# Altium schematic sheet [7]Manettini_e_Bottoni.SchDoc([7]Manettini_e_Bottoni)
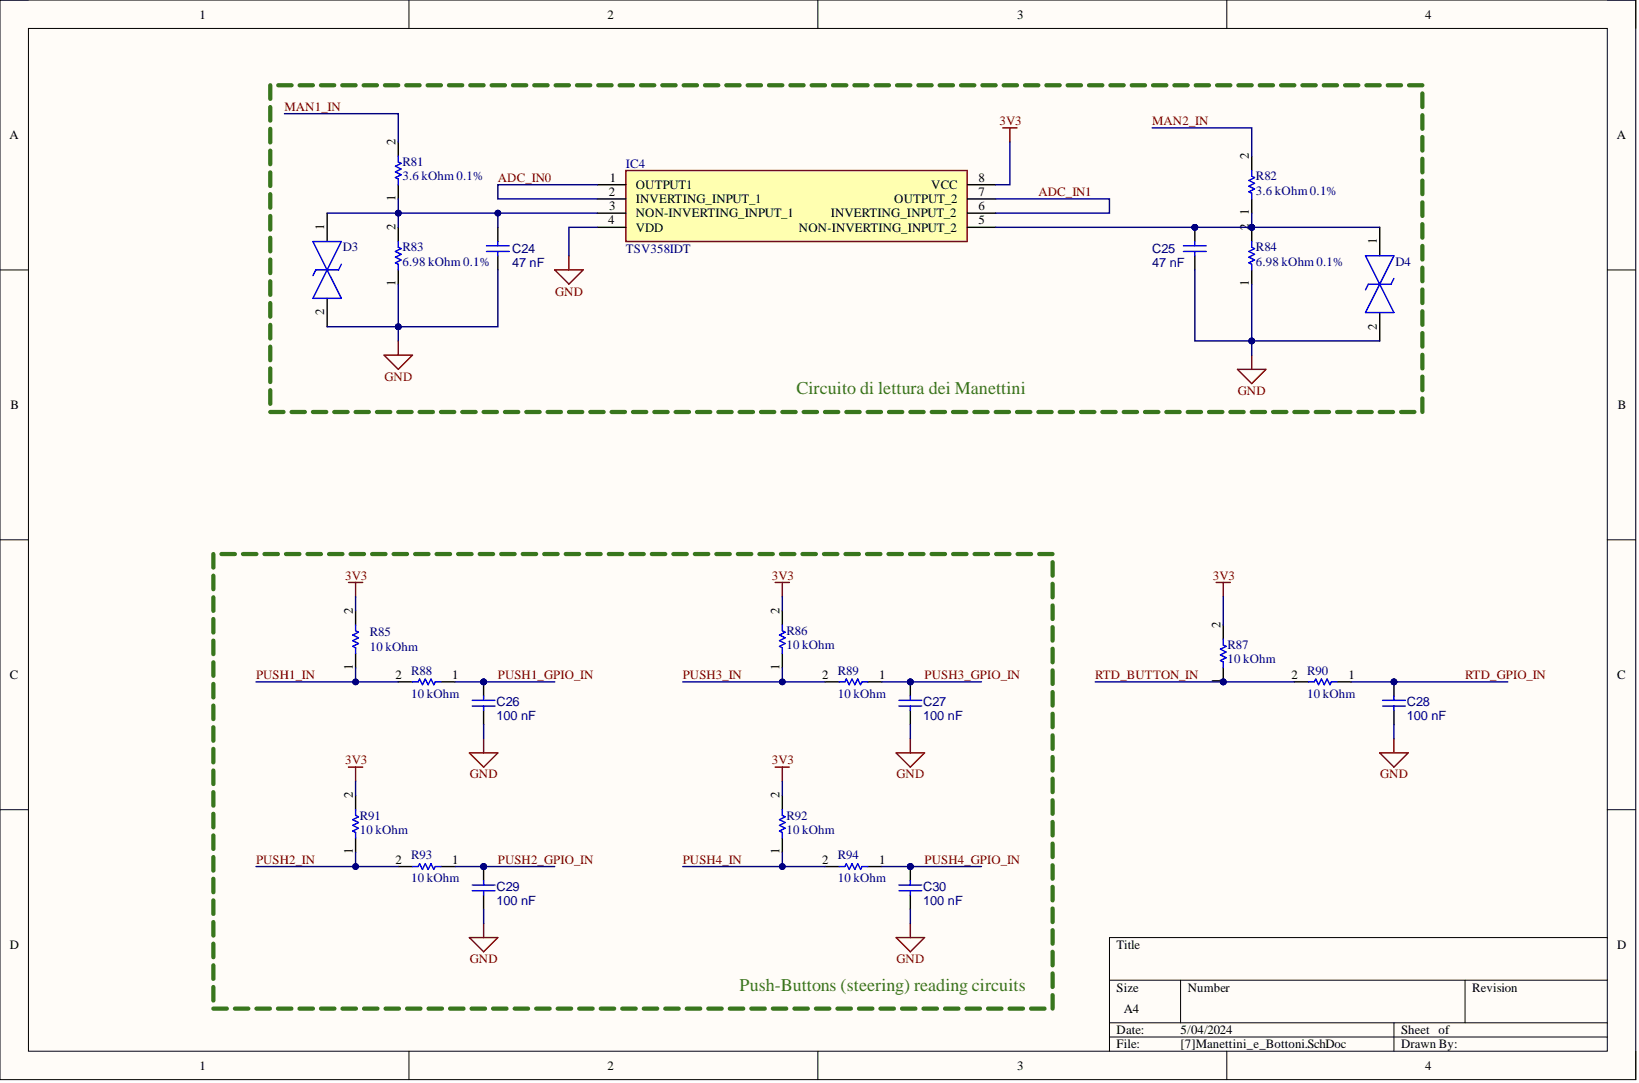
[diagram p0: [7]Manettini_e_Bottoni.SchDoc]

## Parts
C24  47 nF
C25  47 nF
C26  100 nF
C27  100 nF
C28  100 nF
C29  100 nF
C30  100 nF
D3  SMAJ30CA-TR  (value not drawn)
D4  SMAJ30CA-TR  (value not drawn)
IC4  TSV358IDT
R81  3.6 kOhm 0.1%
R82  3.6 kOhm 0.1%
R83  6.98 kOhm 0.1%
R84  6.98 kOhm 0.1%
R85  10 kOhm
R86  10 kOhm
R87  10 kOhm
R88  10 kOhm
R89  10 kOhm
R90  10 kOhm
R91  10 kOhm
R92  10 kOhm
R93  10 kOhm
R94  10 kOhm

## Nets
3V3: IC4.8(VCC) R85.2 R86.2 R87.2 R91.2 R92.2
GND: C24.1 C25.1 C26.1 C27.1 C28.1 C29.1 C30.1 D3.2 D4.2 IC4.4(VDD) R83.1 R84.1
ADC_IN0: IC4.1(OUTPUT1) IC4.2(INVERTING_INPUT_1)
ADC_IN1: IC4.6(INVERTING_INPUT_2) IC4.7(OUTPUT_2)
MAN1_IN: R81.2
MAN2_IN: R82.2
PUSH1_GPIO_IN: C26.2 R88.1
PUSH1_IN: R85.1 R88.2
PUSH2_GPIO_IN: C29.2 R93.1
PUSH2_IN: R91.1 R93.2
PUSH3_GPIO_IN: C27.2 R89.1
PUSH3_IN: R86.1 R89.2
PUSH4_GPIO_IN: C30.2 R94.1
PUSH4_IN: R92.1 R94.2
RTD_BUTTON_IN: R87.1 R90.2
RTD_GPIO_IN: C28.2 R90.1
NetC24_2: C24.2 D3.1 IC4.3(NON-INVERTING_INPUT_1) R81.1 R83.2
NetC25_2: C25.2 D4.1 IC4.5(NON-INVERTING_INPUT_2) R82.1 R84.2

## Library data of the parts
C24 C25 = CMP-001-00085-5: VJ0805Y473KXBAT; Tech: SMD; Component Kind: Standard; ComponentLink1Description: Website; Package: 0805; Library Name: EMEA- Squadra Corse PoliTO-  Politecnico di Torino
C26-C30 = CMP-001-00085-5: VJ0805Y104MXATW1BC; Tech: SMD; Component Kind: Standard; ComponentLink1Description: Website; Package: 0805; Library Name: EMEA- Squadra Corse PoliTO-  Politecnico di Torino
D3 D4 = CMP-004-000005-1: TVS Diode Bi-Directional SMAJ30CA-TR 64.3V; Manufacturer_Name: STMicroelectronics; Arrow Part Number: SMAJ30CA-TR; Arrow Price/Stock: https://www.arrow.com/en/products/smaj30ca-tr/stmicroelectronics?region=nac; Component Kind: Standard; Datasheet Link: https://www.st.com/resource/en/datasheet/smaj10ca.pdf; Design Item ID: SMAJ30CA-TR; Height: 2.65mm; Manufacturer_Part_Number: SMAJ30CA-TR; Mouser Part Number: 511-SMAJ30CA-TR; Mouser Price/Stock: https://www.mouser.co.uk/ProductDetail/STMicroelectronics/SMAJ30CA-TR?qs=0TJjK1waviDY0EkLaKH12g%3D%3D; Source: SamacSys.SchLib; Library Name: EMEA- Squadra Corse PoliTO-  Politecnico di Torino
IC4 = CMP-030-000058-1: Manufacturer_Name: STMicroelectronics; Arrow Part Number: TSV358IDT; Arrow Price/Stock: https://www.arrow.com/en/products/tsv358idt/stmicroelectronics; Component Kind: Standard; Datasheet Link: http://www.st.com/web/en/resource/technical/document/datasheet/CD00063292.pdf; Description: TSV358IDT; Design Item ID: TSV358IDT; Height: 1.75mm; Manufacturer_Part_Number: TSV358IDT; Mouser Part Number: 511-TSV358IDT; Mouser Price/Stock: https://www.mouser.com/Search/Refine.aspx?Keyword=511-TSV358IDT; Source: SamacSys.SchLib; Library Name: EMEA- Squadra Corse PoliTO-  Politecnico di Torino
R81 R82 = CMP-00010-3: TNPW06033K60BEEA; Component Kind: Standard; Library Name: EMEA- Squadra Corse PoliTO-  Politecnico di Torino
R83 R84 = CMP-00010-3: TNPW06036K98BEEA; Component Kind: Standard; Library Name: EMEA- Squadra Corse PoliTO-  Politecnico di Torino
R85-R94 = CMP-00010-3: CRCW060310K0FKEAK; Component Kind: Standard; Library Name: EMEA- Squadra Corse PoliTO-  Politecnico di Torino
